# Altium schematic sheet Sheet1.SchDoc
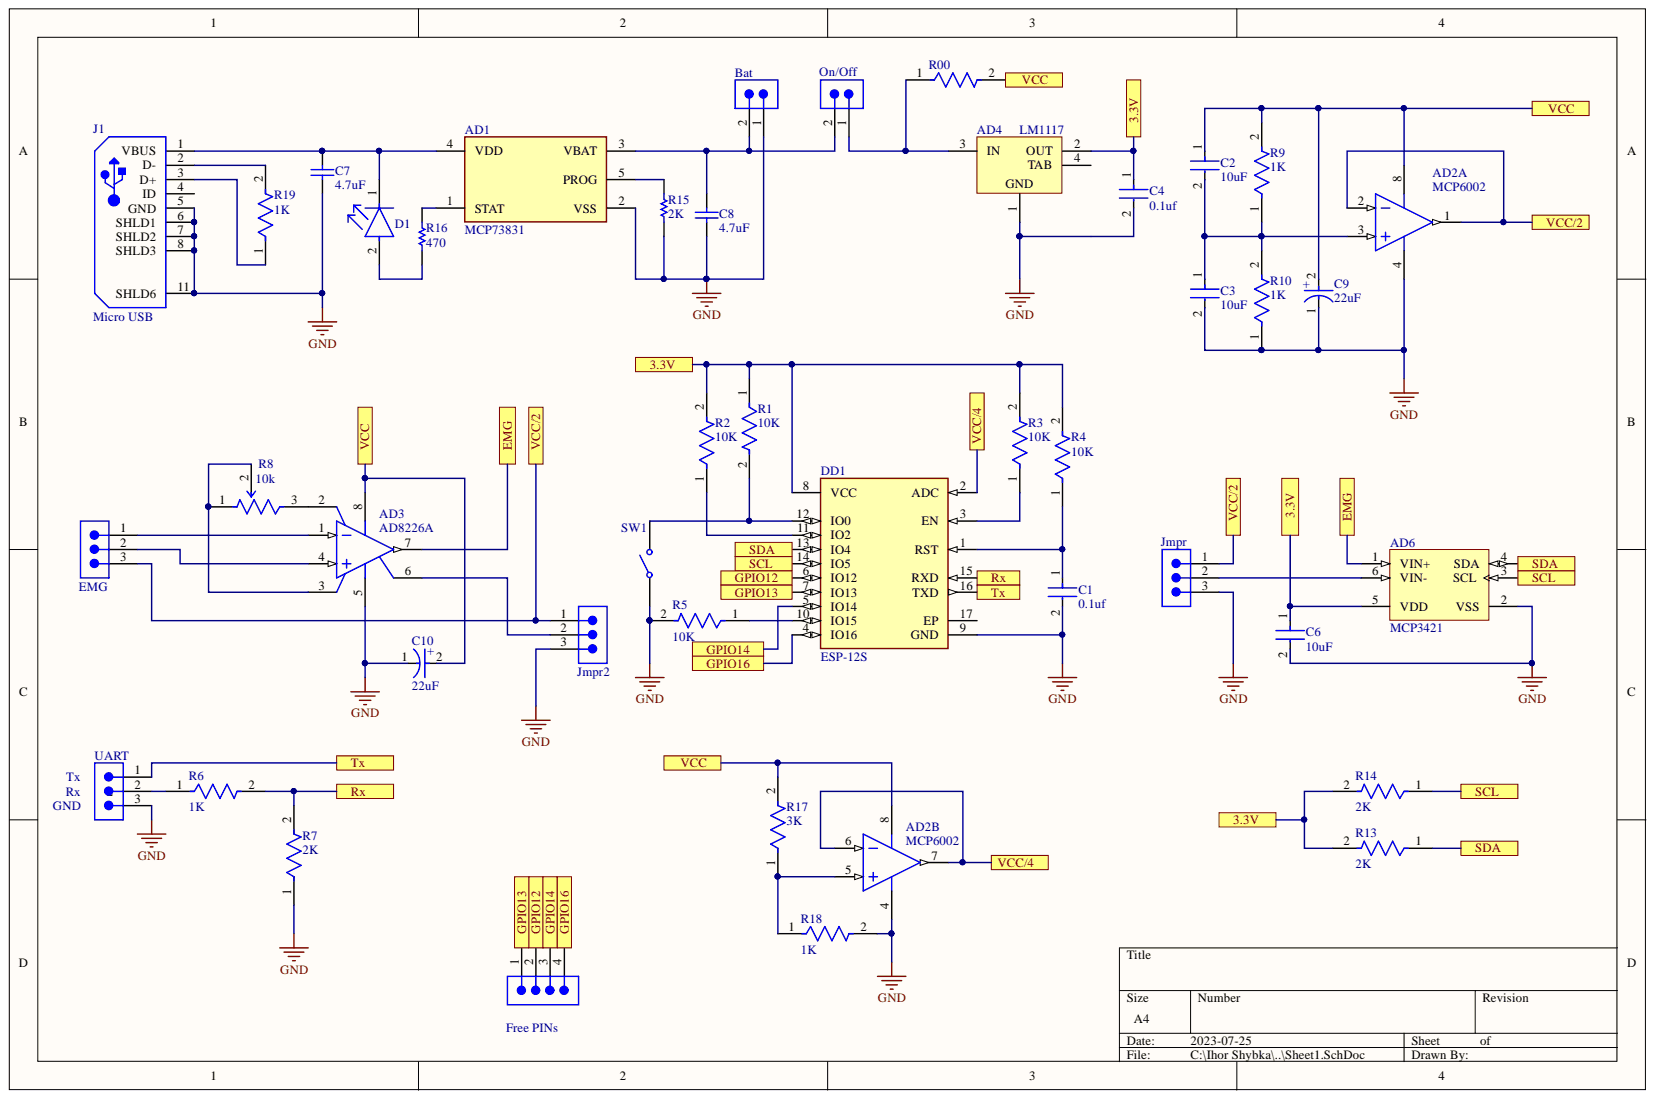
[diagram p0: Sheet1.SchDoc]

## Parts
AD1  MCP73831  [FP-C04-091-OT-IPC_B]
AD2  MCP6002  [SOIC-SN8_M]  (parts A,B)
AD3  AD8226A  [ADI-RM-8_L]
AD4  LM1117  [DCY0004A_L]
AD6  MCP3421  [SOT-23-CH6_M]
Bat  TSW-102-26-G-S  [SMTC-TSW-102-26-XX-S]  (value not drawn)
C1  0.1uf  [FP-0805-L_2_0_0_1-W_1_25-IPC_C]
C2  10uF  [FP-0805-L_2_0_0_1-W_1_25-IPC_C]
C3  10uF  [FP-0805-L_2_0_0_1-W_1_25-IPC_C]
C4  0.1uf  [FP-0805-L_2_0_0_1-W_1_25-IPC_C]
C6  10uF  [FP-0805-L_2_0_0_1-W_1_25-IPC_C]
C7  4.7uF  [CAPC2013X145X50LL20T20]
C8  4.7uF  [CAPC2013X145X50LL20T20]
C9  22uF  [FP-NLJP-MFG]
C10  22uF  [FP-NLJP-MFG]
D1  LTST-C190GKT  [FP-LTST-C190GKT-MFG]  (value not drawn)
DD1  ESP-12S  [FP-ESP-12S-MFG]
EMG  TSW-103-07-T-S  [SMTC-TSW-103-07-X-S]  (value not drawn)
Free_PINs  TSW-104-08-L-S  [SMTC-TSW-104-08-X-S]  (value not drawn)
J1  Micro USB  [USB-MICRO-B_V]
Jmpr  TSW-103-07-T-S  [SMTC-TSW-103-07-X-S]  (value not drawn)
Jmpr2  TSW-103-07-T-S  [SMTC-TSW-103-07-X-S]  (value not drawn)
On/Off  TSW-102-26-G-S  [SMTC-TSW-102-26-XX-S]  (value not drawn)
R00  0Omh  [FP-RP732B-MFG]  (value not drawn)
R1  10K  [FP-RG2012-IPC_C]
R2  10K  [FP-RG2012-IPC_C]
R3  10K  [FP-RG2012-IPC_C]
R4  10K  [FP-RG2012-IPC_C]
R5  10K  [FP-RG2012-IPC_C]
R6  1K  [FP-RG2012-IPC_C]
R7  2K  [FP-RG2012-IPC_C]
R8  10k  [FP-TC33X-2-MFG]
R9  1K  [FP-RG2012-IPC_C]
R10  1K  [FP-RG2012-IPC_C]
R13  2K  [FP-RG2012-IPC_C]
R14  2K  [FP-RG2012-IPC_C]
R15  2K  [RESC2013X60X30ML20T20]
R16  470  [RESC2013X60X30ML20T20]
R17  3K  [FP-RG2012-IPC_C]
R18  1K  [FP-RG2012-IPC_C]
R19  1K  [FP-RG2012-IPC_C]
SW1  Bootloader mode  [434111050826]  (value not drawn)
UART  TSW-103-07-T-S  [SMTC-TSW-103-07-X-S]  (value not drawn)

## Ports
3.3V, EMG, GPIO12, GPIO13, GPIO14, GPIO16, Rx, SCL, SDA, Tx, VCC, VCC/2, VCC/4

## Nets
GND: AD1.2(VSS) AD2.4 AD3.5 AD4.1 AD6.2(VSS) Bat.1 C1.2 C3.2 C4.2 C6.2 C7.1 C8.1 C9.1 C10.1 DD1.9(GND) J1.5(GND) J1.6(SHLD1) J1.7(SHLD2) J1.8(SHLD3) J1.11(SHLD6) Jmpr.3 Jmpr2.3 R5.2 R7.1 R10.1 R15.1 R18.2 SW1.1 UART.3
3.3V: AD4.2(OUT) AD6.5(VDD) C4.1 C6.1 DD1.8(VCC) R1.1 R2.2 R3.2 R4.2 R13.2 R14.2 ; port 3.3V
EMG: AD3.7 AD6.1(VIN+) ; port EMG
GPIO12: DD1.6(IO12) Free_PINs.2 ; port GPIO12
GPIO13: DD1.7(IO13) Free_PINs.1 ; port GPIO13
GPIO14: DD1.5(IO14) Free_PINs.3 ; port GPIO14
GPIO16: DD1.4(IO16) Free_PINs.4 ; port GPIO16
Rx: DD1.15(RXD) R6.2 R7.2 ; port Rx
SCL: AD6.3(SCL) DD1.14(IO5) R14.1 ; port SCL
SDA: AD6.4(SDA) DD1.13(IO4) R13.1 ; port SDA
Tx: DD1.16(TXD) UART.1 ; port Tx
VCC: AD2.8 AD3.8 C2.1 C9.2 C10.2 R00.2 R9.2 R17.2 ; port VCC
VCC/2: AD2.1 AD2.2 EMG.3 Jmpr.1 Jmpr2.1 ; port VCC/2
VCC/4: AD2.6 AD2.7 DD1.2(ADC) ; port VCC/4
NetAD1_1: AD1.1(STAT) R16.2
NetAD1_3: AD1.3(VBAT) Bat.2 C8.2 On/Off.2
NetAD1_4: AD1.4(VDD) C7.2 D1.1 J1.1(VBUS)
NetAD1_5: AD1.5(PROG) R15.2
NetAD2_3: AD2.3 C2.2 C3.1 R9.1 R10.2
NetAD2_5: AD2.5 R17.1 R18.1
NetAD3_1: AD3.1 EMG.1
NetAD3_2: AD3.2 R8.3
NetAD3_3: AD3.3 R8.1 R8.2
NetAD3_4: AD3.4 EMG.2
NetAD3_6: AD3.6 Jmpr2.2
NetAD4_3: AD4.3(IN) On/Off.1 R00.1
NetAD6_6: AD6.6(VIN-) Jmpr.2
NetC1_1: C1.1 DD1.1(RST) R4.1
NetD1_2: D1.2 R16.1
NetDD1_3: DD1.3(EN) R3.1
NetDD1_10: DD1.10(IO15) R5.1
NetDD1_11: DD1.11(IO2) R2.1
NetDD1_12: DD1.12(IO0) R1.2 SW1.2
NetJ1_2: J1.2(D-) R19.2
NetJ1_3: J1.3(D+) R19.1
NetR6_1: R6.1 UART.2

Not connected: AD4 4(TAB); DD1 17(EP); J1 4(ID)

## Library data of the parts
AD1 = CMP-0133-00143-2: IC CONTROLLR LI-ION 4.2V SOT23-5; Drain to Source Resistance: 350mR; Max Charge Current: 500mA; Max Input Voltage: 6V; Max Output Voltage: 4.5V; Max Power Dissipation: 1.54W; Min Output Voltage: 4.168V; Min Supply Voltage: 3.75V; Number of Cells: 1; Voltage Rating: 4.534V; Case/Package: SOT-23; Component Kind: Standard; Contact Plating: Tin; Height: 0.051inch; Lead Free: Lead Free; Length: 0.122inch; Library Name: Altium Content Vault; Max Operating Temperature: 85°C; Max Supply Voltage: 6V; Min Operating Temperature: -40°C; Mount: Surface Mount; Number of Channels: 1; Number of Outputs: 1; Number of Pins: 5; Output Current: 500mA; Output Voltage: 4.2V; Packaging: Tape and Reel; Radiation Hardening: No; REACH SVHC: No SVHC; Termination: SMD/SMT; Weight: 0.00709oz; Width: 0.071inch
AD2 = CMP-0187-00365-3: None; Amplifier Type: GENERAL PURPOSE; Bandwidth: 1MHz; Common Mode Rejection Ratio: 60dB; Input Bias Current: 1pA; Input Offset Voltage (Vos): 4.5mV; Max Supply Voltage: 6V; Min Supply Voltage: 1.8V; Nominal Supply Current: 100uA; Number of Amplifiers: 2; Operating Supply Voltage: 5.5V; Output Current: 23mA; Power Supply Rejection Ratio (PSRR): 86dB; Slew Rate: 0.6V/µs; Voltage Gain: 112dB; Case/Package: SOIC; Component Kind: Standard; Gain Bandwidth Product: 1MHz; Height: 1.25mm; Input Offset Voltage-Max: 4.5mV; Lead Free: Lead Free; Length: 4.9mm; Library Name: Altium Content Vault; Max Operating Temperature: 85°C; Min Operating Temperature: -40°C; Mount: Surface Mount; Number of Channels: 2; Number of Circuits: 2; Number of Elements: 2; Number of Pins: 8; Package Quantity: 100; Packaging: Rail/Tube; Radiation Hardening: No; REACH SVHC: No SVHC; RoHS Compliant: Yes; Simulation: MCP6001; Slew Rate-Nom: 0.6V/µs; Supply Current: 100uA; Technology: CMOS; Unity Gain Bandwidth Product: 1MHz; Width: 3.9mm
AD3 = CMP-2000-05748-1: Wide Supply Range, Rail-to-Rail Output Instrumentation Amplifier, 2.2 to 36 V, -40 to 125 degC, 8-Pin MSOP (RM-8), RoHS, Tube; Manufacturer: Analog Devices; -3db Bandwidth: 1.5MHz; Current - Input Bias: 20nA; Current - Output / Channel: 13mA; Current - Supply: 350µA; Design Resources: PLCandDCSUniversalAnalogInputUsingEither4or6-PinTerminalBlock; EDA / CAD Models: DownloadfromAcceleratedDesigns; Mfg Application Notes: AutomaticExternalDefibrillator(AED)SolutionsElectromagneticFlowMeterSolutionsMotorControlSolution—ServoControlProgrammableLogicControllers(PLCs)SolutionsElectrocardiogram(ECG)Solutions; Number of Circuits: 1; Package Version: 10/2009; PCN Design/Specification: PolyimideAlignmentTarget11/Aug/2015; Product Training Modules: CommonPhotodiodeOp-AmpCircuitProblemsandSolutionsIndirectCurrentFeedbackInstrumentationAmplifierApplicationsGuide; Slew Rate: 0.6V/µs; Voltage - Input Offset: 100µV; Voltage - Supply, Single/Dual (±): 2.2V~36V,±1.35V~18V; Amplifier Type: Instrumentation; Category: IntegratedCircuits(ICs); Component Kind: Standard; Datasheet URL: http://www.analog.com/media/en/technical-documentation/data-sheets/AD8226.pdf; Datasheet Version: Rev. C, 09/2012; Family: Linear-Amplifiers-Instrumentation,OPAmps,BufferAmps; Gain Bandwidth Product: -; Library Name: Altium Content Vault; Manufacturer URL: http://www.analog.com/; Mounting Technology: SurfaceMount; Mounting Type: SurfaceMount; Online Catalog: Instrumentation; Operating Temperature: -40°C~125°C; Output Type: Rail-to-Rail; Package / Case: 8-TSSOP,8-MSOP(0.118",3.00mmWidth); Package Description: 8-Pin Mini Small Outline Package, Body 3 x 3 mm, Pitch 0.65 mm; Package Reference: RM-8; Package URL: http://www.analog.com/static/imported-files/packages/PKG_PDF/MSOP%28RM%29/RM_8.pdf; Packaging: Tube; Series: -; Standard Package: 50; Supplier Device Package: 8-MSOP
AD4 = CMP-0062-01639-4: 800mA Low-Dropout Linear Regulator, 4-pin SOT-223, Pb-Free LM1117; Manufacturer: Texas Instruments; PartNumber: LM1117MPX-3.3/NOPB; CompType: LDO IC; High-Reliability: Y; PackageTopMarking: XYTT*N05A; PowerWise: N; Code_IPC: SOT223-4; Code_JEDEC: TO-261-AA; Component Kind: Standard; ComponentLink1Description: Datasheet URL; ComponentLink1URL: http://www.ti.com/general/docs/lit/getliterature.tsp?genericPartNumber=LM1117-N&fileType=pdf; Library Name: Altium Content Vault; PackageDescription: Molded SOT-223, 6.50 x 3.56 x 1.6mm Body, 4 Lead, 2.3mm Pitch; PackageReference: DCY0004A; PinCount: 4; RoHS: Y
AD6 = CMP-0188-00739-2: 18-Bit Analog-to-Digital Converter with I2C Interface and On-Board Reference, 6-Pin SOT-23, Extended Temperature, Tape and Reel; Code_IPC: SOT26A-6; Component Kind: Standard; ComponentLink1Description: Manufacturer URL; ComponentLink1URL: http://www.microchip.com/; ComponentLink2Description: Datasheet; ComponentLink2URL: http://ww1.microchip.com/downloads/en/DeviceDoc/22003e.pdf; ComponentLink3Description: Package Specification; ComponentLink3URL: http://www.microchip.com/stellent/groups/techpub_sg/documents/packagingspec/en012702.pdf; DatasheetVersion: revE, Aug-2009; Library Name: Altium Content Vault; PackageDescription: 6-Lead Plastic Small Outline Transistor (CH) [SOT-23]; PackageReference: SOT-23-CH6; PackageVersion: revBB, Aug-2009; RevisionNote: URLs added.
Bat On/Off = CMP-1024-00327-1: 0.025" SQ Post Header, Through-hole, Vertical, -55 to 125 degC, 2.54 mm Pitch, 2-Pin, Male, RoHS; Manufacturer: Samtec; Pitch: 2.54 mm; Component Kind: Standard; ComponentLink1Description: Manufacturer URL; ComponentLink1URL: http://www.samtec.com; ComponentLink2Description: Datasheet; ComponentLink2URL: http://www.samtec.com/documents/webfiles/pdf/TSW_TH.PDF; ComponentLink3Description: Package Specification; ComponentLink3URL: http://www.samtec.com/documents/webfiles/cpdf/TSW-XXX-XX-XXX-X-XX-XXX-MKT.pdf; DatasheetVersion: F-214; Library Name: Altium Content Vault; Mounting Technology: Through-hole; Orientation: Vertical; PackageDescription: .025[0.64] SQ. Post Terminal Strip Assembly; PackageReference: TSW-102-26-XX-S; PackageVersion: DM, 8/1988; PartNumber: TSW-102-26-G-S; RoHS: True; Temperature Range: -55 to 125 degC
C1 C3 C4 = CMP-14477-004636-2: 0.1uF 0805; Capacitance: 100nF; Tolerance: 10%; Voltage: 10V; Case/Package: 0805; Case Code (Imperial): 0805; Case Code (Metric): 2012; Component Kind: Standard; Construction: Flat; Depth: 1.25mm; Halogen Free: Halogen Free; Height: 1.25mm; Lead Free: Lead Free; Length: 2mm; Library Name: Altium Content Vault; Max Operating Temperature: 125°C; Min Operating Temperature: -55°C; Mount: Surface Mount; Number of Pins: 2; Package Quantity: 3000; Packaging: Tape and Reel; Radiation Hardening: No; Resistor Type: Ceramic; RoHS Compliant: Yes; Series: CFCAP™; Termination: SMD/SMT; Thickness: 0.053inch; Voltage Rating: 10V; Voltage Rating (DC): 10V; Weight: 0.000194oz; Width: 1.25mm
C2 C6 = CMP-14477-004636-2: 10uF 0805; Capacitance: 100nF; Tolerance: 10%; Voltage: 10V; Case/Package: 0805; Case Code (Imperial): 0805; Case Code (Metric): 2012; Component Kind: Standard; Construction: Flat; Depth: 1.25mm; Halogen Free: Halogen Free; Height: 1.25mm; Lead Free: Lead Free; Length: 2mm; Library Name: Altium Content Vault; Max Operating Temperature: 125°C; Min Operating Temperature: -55°C; Mount: Surface Mount; Number of Pins: 2; Package Quantity: 3000; Packaging: Tape and Reel; Radiation Hardening: No; Resistor Type: Ceramic; RoHS Compliant: Yes; Series: CFCAP™; Termination: SMD/SMT; Thickness: 0.053inch; Voltage Rating: 10V; Voltage Rating (DC): 10V; Weight: 0.000194oz; Width: 1.25mm
C7 C8 = CMP-2007-01344-1: 4.7uF 0805; Manufacturer: Vitramon; Part Number: VJ0805A472FXJTW1BC; Component Kind: Standard; Library Name: Altium Content Vault
C9 C10 = CMP-04425-000003-1: CAP NIOB OXIDE 22UF 20% 4V 0805; Manufacturer: AVX Corporation; Manufacturer Part Number: NLJP226M004R4000; Esr: 4000mΩ; Leakage Current: 8.8uA; Life: 1000Hours; Material: Niobium Oxide; Ripple Current: 134mA; Temperature Coefficient: +25/-20%; Automotive: No; Capacitance: 22uF; Category: Cap; Component Kind: Standard; ComponentLink2Description: Datasheet; ComponentLink2URL: http://datasheets.avx.com/NLJ.pdf; Device Class L1: Passive Components; Device Class L2: Capacitors; Device Class L3: Niobium Oxide; Digikey Description: CAP NIOB OXIDE 22UF 20% 4V 0805; Footprint Url: https://www.avx.com/download/software/literature/AVX-Footprint-Library.pdf; Height: 1.5mm; Lead Free: Yes; Library Name: Altium Content Vault; Mouser Description: Niobium Oxide Capacitors 4volts 22uF 20% ESR=4000mOhms; Octopart: https://octopart.com/nljp226m004r4000-avx-10566975; Package: NLJP; RoHS: TRUE; Temperature: -55°C to +105°C; Temperature Range High: +105°C; Temperature Range Low: -55°C; Tolerance: 20%; Value: 22uF; Voltage Rating: 4V
D1 = CMP-22017-000005-2: LED GREEN CLEAR CHIP SMD; Dominant Wavelength: 569nm; Forward Current: 30mA; Forward Voltage: 2.1V; Lens Color: Colorless; Luminous Intensity: 6mcd; Number of Elements: 1; Power Dissipation: 100mW; Reverse Voltage: 5V; Test Current: 10mA; Viewing Angle: 130°; Voltage: 2V; Wavelength: 565nm; Case/Package: 0603; Case Code (Imperial): 0603; Case Code (Metric): 1608; Color: Green; Component Kind: Standard; Depth: 0.8mm; Height: 0.8mm; Illumination Color: Green; Lead Free: Lead Free; Length: 1.6mm; Lens Style: Clear; Lens Transparency: Clear; Library Name: Altium Content Vault; Max Operating Temperature: 85°C; Min Operating Temperature: -55°C; Mount: Surface Mount; Number of LEDs: 1; Number of Pins: 2; Package Quantity: 1; Packaging: Tape and Reel; Power Rating: 100mW; Radiation Hardening: No; Width: 0.8mm
DD1 = CMP-204316-000002-1: RX TXRX MOD WIFI PCB TRACE SMD; Data Rate: 54Mbps; Frequency: 2.484GHz; Interface: I2C; Sensitivity: -90dBm; Component Kind: Standard; Library Name: Altium Content Vault; Series: -
EMG Jmpr Jmpr2 UART = CMP-1024-00332-1: 0.025" SQ Post Header, Through-hole, Vertical, -55 to 105 degC, 2.54 mm Pitch, 3-Pin, Male, RoHS; Manufacturer: Samtec; Pitch: 2.54 mm; Component Kind: Standard; ComponentLink1Description: Manufacturer URL; ComponentLink1URL: http://www.samtec.com; ComponentLink2Description: Datasheet; ComponentLink2URL: http://www.samtec.com/documents/webfiles/pdf/TSW_TH.PDF; ComponentLink3Description: Package Specification; ComponentLink3URL: http://www.samtec.com/documents/webfiles/cpdf/TSW-XXX-XX-XXX-X-XX-XXX-MKT.pdf; DatasheetVersion: F-214; Library Name: Altium Content Vault; Mounting Technology: Through-hole; Orientation: Vertical; PackageDescription: .025[0.64] SQ. Post Terminal Strip Assembly; PackageReference: TSW-103-07-X-S; PackageVersion: DM, 8/1988; PartNumber: TSW-103-07-T-S; RoHS: True; Temperature Range: -55 to 105 degC
Free_PINs = CMP-1024-00358-1: 0.025" SQ Post Header, Through-hole, Vertical, -55 to 125 degC, 2.54 mm Pitch, 4-Pin, Male, RoHS; Manufacturer: Samtec; Pitch: 2.54 mm; Component Kind: Standard; ComponentLink1Description: Manufacturer URL; ComponentLink1URL: http://www.samtec.com; ComponentLink2Description: Datasheet; ComponentLink2URL: http://www.samtec.com/documents/webfiles/pdf/TSW_TH.PDF; ComponentLink3Description: Package Specification; ComponentLink3URL: http://www.samtec.com/documents/webfiles/cpdf/TSW-XXX-XX-XXX-X-XX-XXX-MKT.pdf; DatasheetVersion: F-214; Library Name: Altium Content Vault; Mounting Technology: Through-hole; Orientation: Vertical; PackageDescription: .025[0.64] SQ. Post Terminal Strip Assembly; PackageReference: TSW-104-08-X-S; PackageVersion: DM, 8/1988; PartNumber: TSW-104-08-L-S; RoHS: True; Temperature Range: -55 to 125 degC
J1 = CMP-2000-05827-1: Micro-USB B Receptacle, Right Angle, Bottom Mount, Surface Mount, with Solder Tabs, -30 to 85 degC, 5-Pin USB, RoHS, Tape and Reel; Manufacturer: Molex; 3D Model: 105017-0001.stp; Catalog Drawings: 1050170001; Circuits: 5; Connector Type: USB-microB; Featured Product: Micro-USBConnectorsUSBConnectors; Mating Products: WM17145-ND-CABLEMICROUSBBTOSTDA1.0MWM17147-ND-CABLEMICROUSBBTOSTDA2.0MWM17146-ND-CABLEMICROUSBBTOSTDA1.5M; Online Catalog: Molex-MicroUSB; Other Names: WM1399DKR; Package Version: Rev. F, 12/2012; PCN Assembly/Origin: ResinSupplier21/Oct/2015; Voltage - Max: 30V; Category: Connectors,Interconnects; Component Kind: Standard; Datasheet URL: http://www.molex.com/elqNow/elqRedir.htm?ref=http://www.molex.com/webdocs/datasheets/pdf/en-us/1050170001_IO_CONNECTORS.pdf; Datasheet Version: 03/2016; Family: USB,DVI,HDMIConnectors; Features: Shielded,SolderRetention; Gender: Receptacle; Ingress Protection: -; Library Name: Altium Content Vault; Manufacturer URL: http://www.molex.com/; Mounting Technology: SurfaceMount; Mounting Type: BoardCutout,SurfaceMount,RightAngle; Number of Contacts: 5; Package Description: 5-Leads USB, Micro B, Body 7.5 x 5 mm, Pitch 0.65 mm; Package Reference: MICRO-B; Package URL: http://www.molex.com/pdm_docs/sd/1050170001_sd.pdf; Packaging: Digi-Reel®; RoHS Information: 1050170001CertofCompliance; Series: -; Specifications: -; Standard Package: 1
R00 = CMP-03211-009864-1: 1206 0Ω; Manufacturer: TE Connectivity; Manufacturer Part Number: RP73D2B10RBTG; Power Rating: 0.25W; Resistance: 10Ω; Temperature Coefficient: 15ppm/°C; Tolerance: 0.1%; Value: 10Ω; Voltage Rating: 200V; Automotive: No; Category: Res; Component Kind: Standard; ComponentLink2Description: Datasheet; ComponentLink2URL: https://www.te.com/commerce/DocumentDelivery/DDEController?Action=showdoc&DocId=Data+Sheet%7F1773272%7FL%7Fpdf%7FEnglish%7FENG_DS_1773272_L.pdf%7F8-1879255-6; Composition: Thin Film; Device Class L1: Passive Components; Device Class L2: Resistors; Device Class L3: Chip SMD Resistors; Height: 0.65mm; Ipc Land Pattern Name: RESC305155X55; Lead Free: Yes; Library Name: Altium Content Vault; Octopart: https://octopart.com/rp73d2b10rbtg-te+connectivity-42012237; octopart_url: https://octopart.com/rp73d2b10rbtg-te+connectivity-42012237; Package: RP732B; packaging: 250 cut tape length; RoHS: TRUE; Series: RP73; Temperature: -55°C to 155°C; Temperature Range High: 155°C; Temperature Range Low: -55°C
R1-R5 = CMP-2001-04803-2: 0805, 10kΩ; Max Power Dissipation: 125mW; Resistance: 10kR; Temperature Coefficient: 10ppm/°C; Tolerance: 0.05%; Voltage Rating: 150V; Case/Package: 0805; Case Code (Imperial): 0805; Case Code (Metric): 2012; Component Kind: Standard; Features: Automotive AEC-Q200; Height: 0.02inch; Lead Free: Lead Free; Length: 0.079inch; Library Name: Altium Content Vault; Max Operating Temperature: 155°C; Min Operating Temperature: -55°C; Number of Terminations: 2; Package Quantity: 5000; Packaging: Tape and Reel; Power Rating: 125mW; Resistor Type: Thin Film; RoHS Compliant: Yes; Series: RG; Size Code: 0805; Termination: SMD/SMT; Voltage Rating (DC): 150V; Weight: 0.000194oz; Width: 1.2mm
R6 R9 R10 R17-R19 = CMP-2001-04803-2: 0805, 1kΩ; Max Power Dissipation: 125mW; Resistance: 10kR; Temperature Coefficient: 10ppm/°C; Tolerance: 0.05%; Voltage Rating: 150V; Case/Package: 0805; Case Code (Imperial): 0805; Case Code (Metric): 2012; Component Kind: Standard; Features: Automotive AEC-Q200; Height: 0.02inch; Lead Free: Lead Free; Length: 0.079inch; Library Name: Altium Content Vault; Max Operating Temperature: 155°C; Min Operating Temperature: -55°C; Number of Terminations: 2; Package Quantity: 5000; Packaging: Tape and Reel; Power Rating: 125mW; Resistor Type: Thin Film; RoHS Compliant: Yes; Series: RG; Size Code: 0805; Termination: SMD/SMT; Voltage Rating (DC): 150V; Weight: 0.000194oz; Width: 1.2mm
R7 R13 R14 = CMP-2001-04803-2: 0805, 2kΩ; Max Power Dissipation: 125mW; Resistance: 10kR; Temperature Coefficient: 10ppm/°C; Tolerance: 0.05%; Voltage Rating: 150V; Case/Package: 0805; Case Code (Imperial): 0805; Case Code (Metric): 2012; Component Kind: Standard; Features: Automotive AEC-Q200; Height: 0.02inch; Lead Free: Lead Free; Length: 0.079inch; Library Name: Altium Content Vault; Max Operating Temperature: 155°C; Min Operating Temperature: -55°C; Number of Terminations: 2; Package Quantity: 5000; Packaging: Tape and Reel; Power Rating: 125mW; Resistor Type: Thin Film; RoHS Compliant: Yes; Series: RG; Size Code: 0805; Termination: SMD/SMT; Voltage Rating (DC): 150V; Weight: 0.000194oz; Width: 1.2mm
R8 = CMP-07234-000040-1: 0-10K; Max Power Dissipation: 100mW; Number of Turns: 1; Resistance: 100kR; Resistance Tolerance: 25%; Temperature Coefficient: 250ppm/°C; Voltage Rating (DC): 50V; Color: Silver; Component Kind: Standard; Depth: 3.8mm; Height: 0.047inch; Length: 3mm; Library Name: Altium Content Vault; Max Operating Temperature: 100°C; Military Standard: Not; Min Operating Temperature: -40°C; Mount: Surface Mount; Package Quantity: 2500; Packaging: Tape and Reel; Power Rating: 100mW; Radiation Hardening: No; REACH SVHC: No SVHC; Resistor Type: Carbon; RoHS Compliant: Yes; Series: TC33; Termination: Foot Print; Tolerance: 25%; Weight: 29.4mg; Width: 0.118inch
R15 = CMP-2001-04656-1: 0805, 2KΩ; Manufacturer: KOA Speer; Part Number: RK73B2ATTD102J; Component Kind: Standard; Library Name: Altium Content Vault
R16 = CMP-2001-04656-1: 0805, 470Ω; Manufacturer: KOA Speer; Part Number: RK73B2ATTD102J; Component Kind: Standard; Library Name: Altium Content Vault
SW1 = CMP-1459-00003-1: WS-TASV SMD Tact Switch 6.0X3.5 mm; Manufacturer: Wuerth Elektronik; NoPins: 02; Component Kind: Standard; ComponentLink1Description: Manufacturer URL; ComponentLink1URL: http://www.we-online.com; ComponentLink2Description: Datasheet; ComponentLink2URL: http://katalog.we-online.de/em/datasheet/434111050826.pdf; Library Name: Altium Content Vault; PackageReference: 434111050826; PartNumber: 434111050826
